# Altium schematic sheet PowerSupply.SchDoc(PowerSupply)
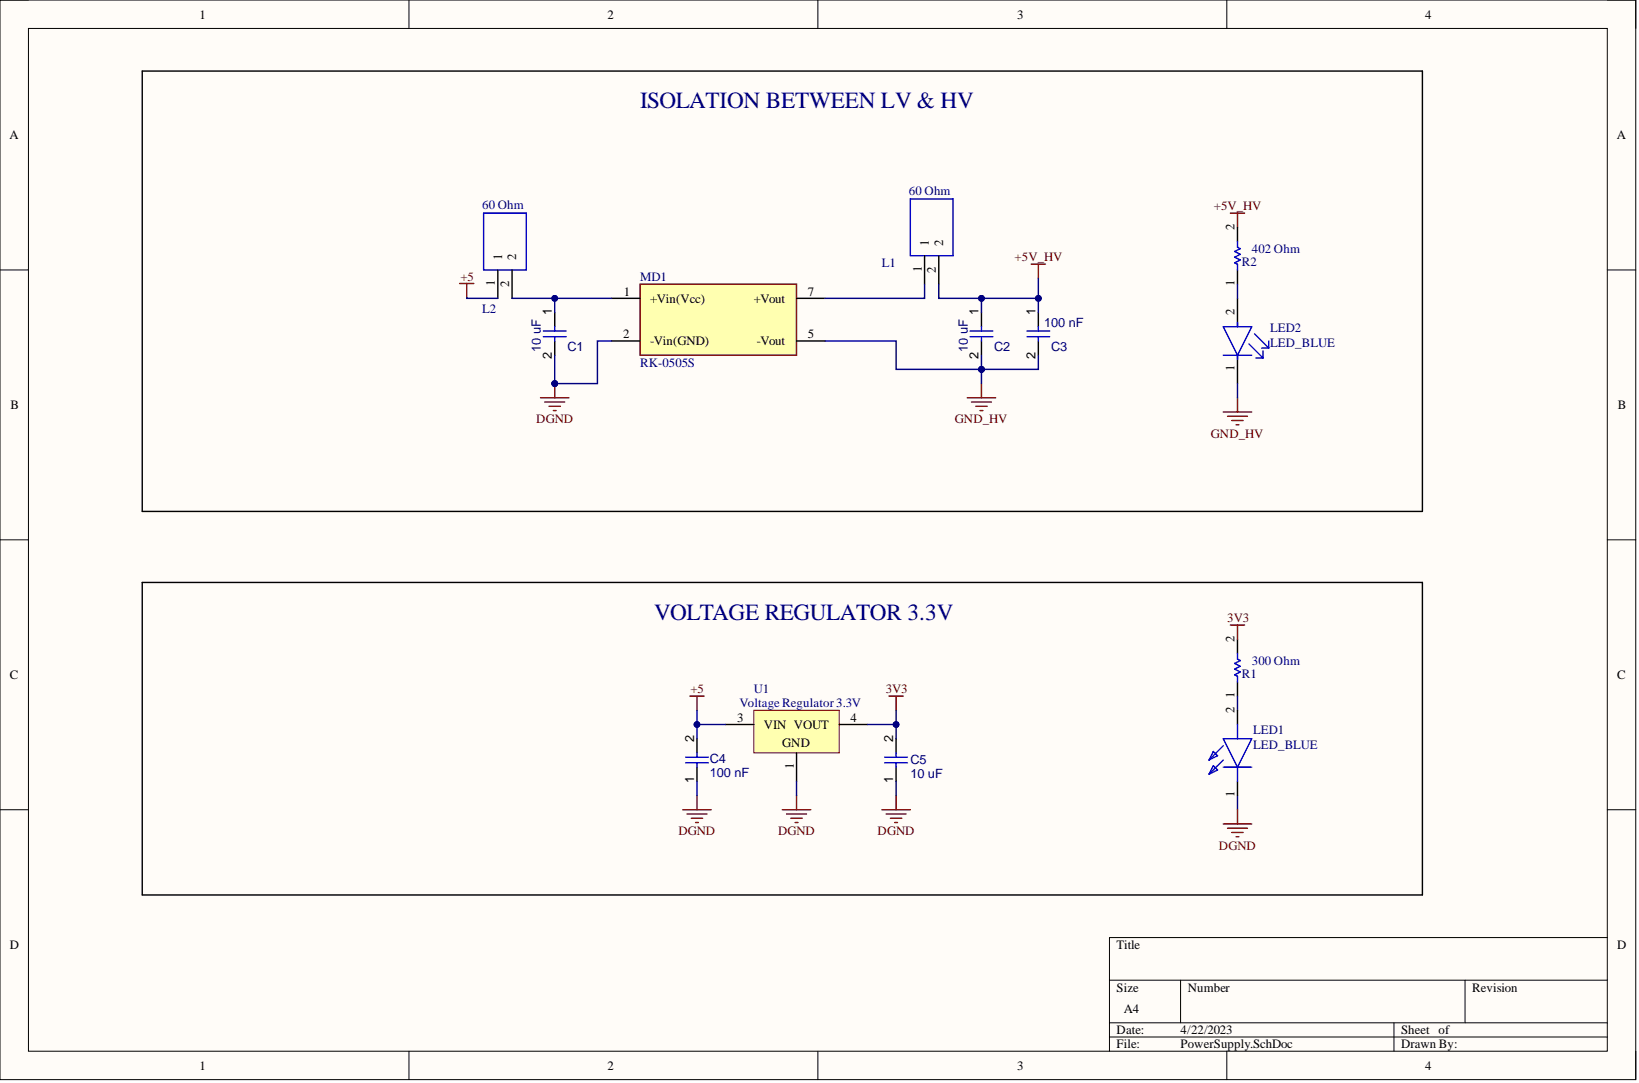
[diagram p0: PowerSupply.SchDoc]

## Parts
C1  10 uF
C2  10 uF
C3  100 nF
C4  100 nF
C5  10 uF
L1  60 Ohm
L2  60 Ohm
LED1  LED_BLUE
LED2  LED_BLUE
MD1  RK-0505S
R1  300 Ohm
R2  402 Ohm
U1  Voltage Regulator 3.3V

## Nets
3V3: C5.2 R1.2 U1.4
+5: C4.2 L2.1 U1.3
+5V_HV: C2.1 C3.1 L1.2 R2.2
DGND: C1.2 C4.1 C5.1 LED1.1 MD1.2(-Vin(GND)) U1.1
GND_HV: C2.2 C3.2 LED2.1 MD1.5(-Vout)
NetC1_1: C1.1 L2.2 MD1.1(+Vin(Vcc))
NetL1_1: L1.1 MD1.7(+Vout)
NetLED1_2: LED1.2 R1.1
NetLED2_2: LED2.2 R2.1

## Library data of the parts
C1 C2 C5 = CMP-001-00085-2: GRM21BR71A106KA73K; Tech: SMD; Component Kind: Standard; ComponentLink1Description: Website; Package: 0805; Library Name: EMEA- Squadra Corse PoliTO-  Politecnico di Torino
  C5: ComponentLink1URL: https://www.mouser.it/ProductDetail/Vishay-Vitramon/VJ0805G106MXQTW1BC?qs=PqoDHHvF64%2FiIFVCZpIM5w%3D%3D
C3 C4 = CMP-001-00085-2: VJ0805Y104KBABE31; Tech: SMD; Component Kind: Standard; ComponentLink1Description: Website; Package: 0805; Library Name: EMEA- Squadra Corse PoliTO-  Politecnico di Torino
L1 L2 = CMP-056-000000-1: ILHB0805ER600V; Tech: SMD; Component Kind: Standard; Package: 0805; Library Name: EMEA- Squadra Corse PoliTO-  Politecnico di Torino
LED1 = CMP-031-000016-1: APT2012LVBC_D; Manufacturer_Name: Kingbright; Manufacturer_Part_Number: APT2012LVBC/D; Tech: SMD; Arrow Part Number: APT2012LVBC/D; Arrow Price/Stock: https://www.arrow.com/en/products/apt2012lvbcd/kingbright; Component Kind: Standard; ComponentLink1Description: Website; ComponentLink1URL: https://www.mouser.it/ProductDetail/Kingbright/APT2012LVBC-D?qs=6oMev5NRZMGErJYT6KZLXg%3D%3D; Datasheet Link: https://componentsearchengine.com/Datasheets/1/APT2012LVBC_D.pdf; Design Item ID: APT2012LVBC_D; Height: 0.85mm; Mouser Part Number: 604-APT2012LVBCD; Mouser Price/Stock: https://www.mouser.co.uk/ProductDetail/Kingbright/APT2012LVBC-D?qs=6oMev5NRZMGErJYT6KZLXg%3D%3D; Package: 0805; Source: SamacSys.SchLib; Library Name: EMEA- Squadra Corse PoliTO-  Politecnico di Torino
LED2 = CMP-007-00036-1: VLMB1300-GS08; Tech: SMD; Component Kind: Standard; ComponentLink1Description: Website; ComponentLink1URL: https://www.mouser.it/ProductDetail/Vishay-Semiconductors/VLMB1300-GS08?qs=uoVnq4yU%252B9K3zw%252BhVoDOXQ%3D%3D; Package: 0603; Library Name: EMEA- Squadra Corse PoliTO-  Politecnico di Torino
MD1 = CMP-49942-000020-1: DC DC CONVERTER 5V 1W; Efficiency: 78%; Isolation Voltage: 4kV; Max Input Voltage: 5.5V; Max Output Current: 200mA; Min Input Voltage: 4.5V; Number of Outputs: 1; Component Kind: Standard; Library Name: Altium Content Vault; Max Operating Temperature: 95°C; Max Power Dissipation: 1W; Min Operating Temperature: -40°C; Mount: Through Hole; Series: TEA 1HI (1W); Voltage - Output 1: 5V
R1 = CMP-009-00179-4: CRCW0603300RFKEA; Tech: SMD; Component Kind: Standard; ComponentLink1Description: Website; ComponentLink1URL: https://www.mouser.it/ProductDetail/Vishay-Dale/CRCW0603300RFKEA?qs=SNwsiWikH8qfoKtotWEteQ%3D%3D; Package: 0603; Library Name: EMEA- Squadra Corse PoliTO-  Politecnico di Torino
R2 = CMP-009-00179-4: CRCW0603402RFKEA; Tech: SMD; Component Kind: Standard; ComponentLink1Description: Website; ComponentLink1URL: https://www.mouser.it/ProductDetail/Vishay-Dale/CRCW0603402RFKEA?qs=PlgT7GGookHxID%2FOfdNhwg%3D%3D; Package: 0603; Library Name: EMEA- Squadra Corse PoliTO-  Politecnico di Torino
U1 = CMP-0244-00089-1: LD1117DT33CTR; Tech: SMD; Component Kind: Standard; ComponentLink1Description: Manufacturer URL; ComponentLink1URL: http://www.st.com; ComponentLink2Description: Datasheet; ComponentLink2URL: http://www.st.com/internet/com/TECHNICAL_RESOURCES/TECHNICAL_LITERATURE/DATASHEET/CD00000544.pdf; ComponentLink3Description: Website; ComponentLink3URL: https://www.mouser.it/ProductDetail/STMicroelectronics/LD1117DT33CTR?qs=rUxiu3TV3%2FNm%2FOyb7vJzpg%3D%3D; DatasheetVersion: 1/11/2011; Library Name: Altium Content Vault; PackageDescription: 3-Pin Transistor Outline Package 2.28 mm Pitch, 9.7 mm Lead Span (DPAK); PackageReference: TO-252; PackageVersion: 2011
